# Altium schematic sheet ESSICC-ALM-INV-GAS.SchDoc(ESSICC-ALM-INV-GAS)
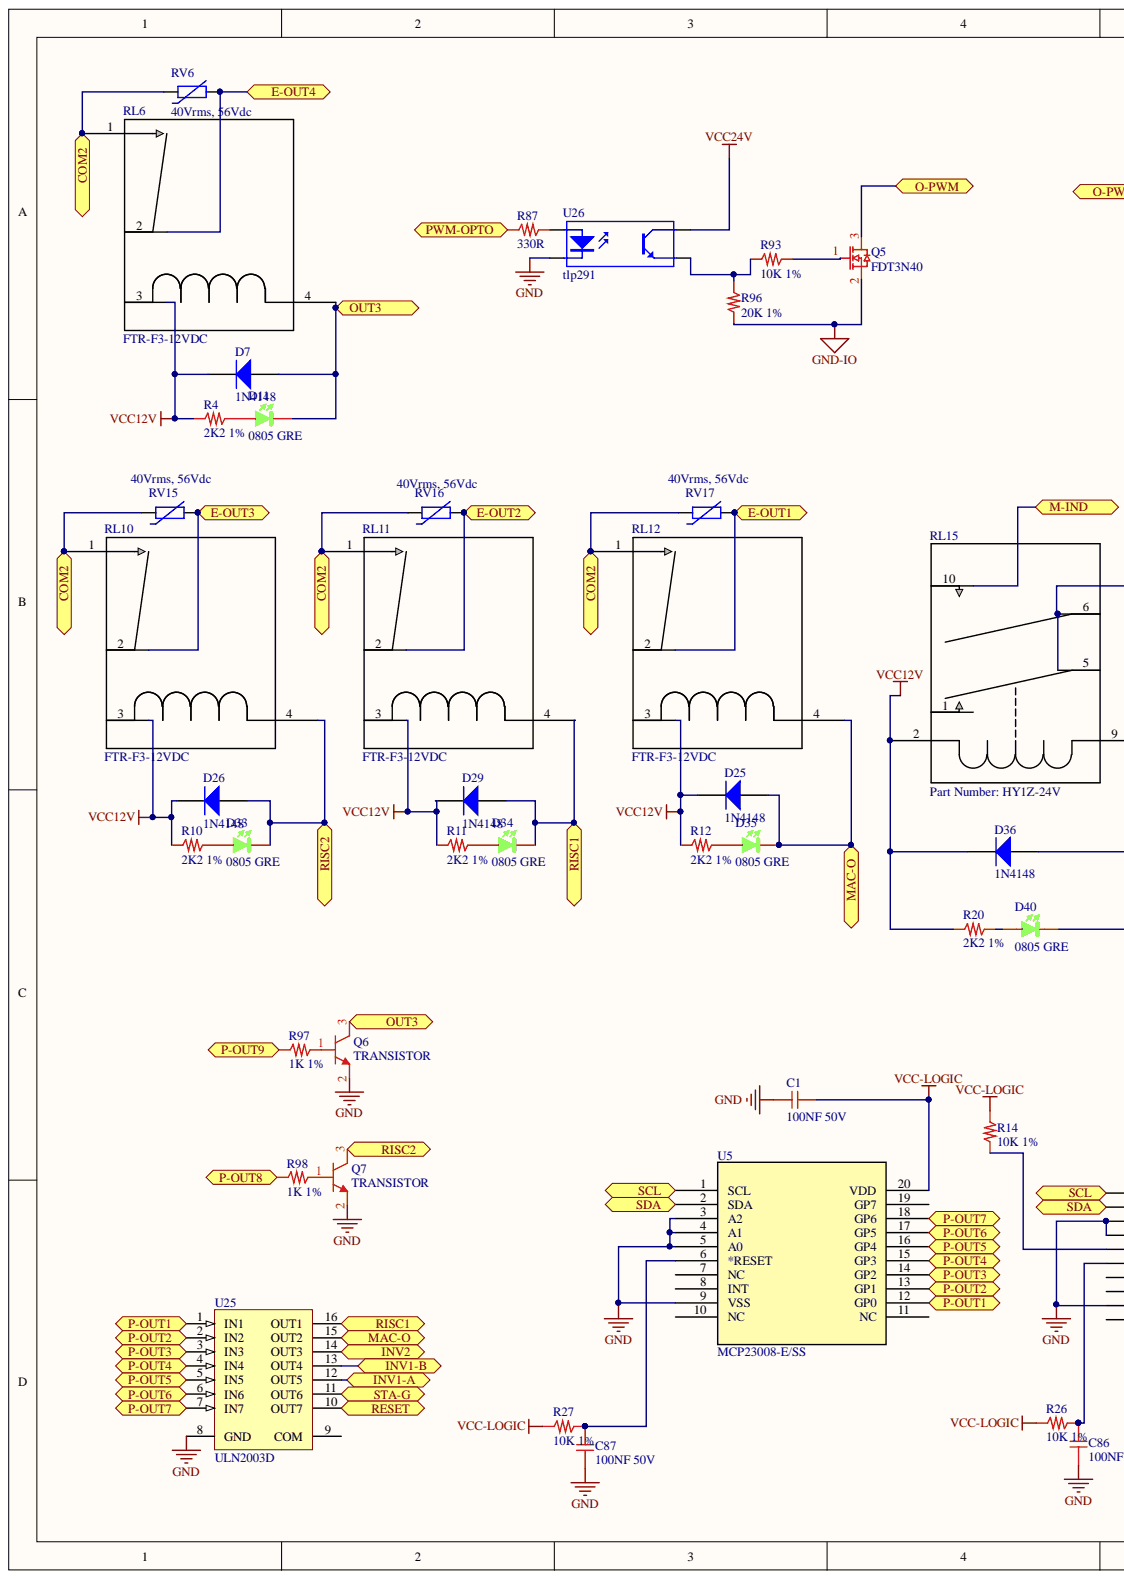
[diagram p0: ESSICC-ALM-INV-GAS.SchDoc, left]
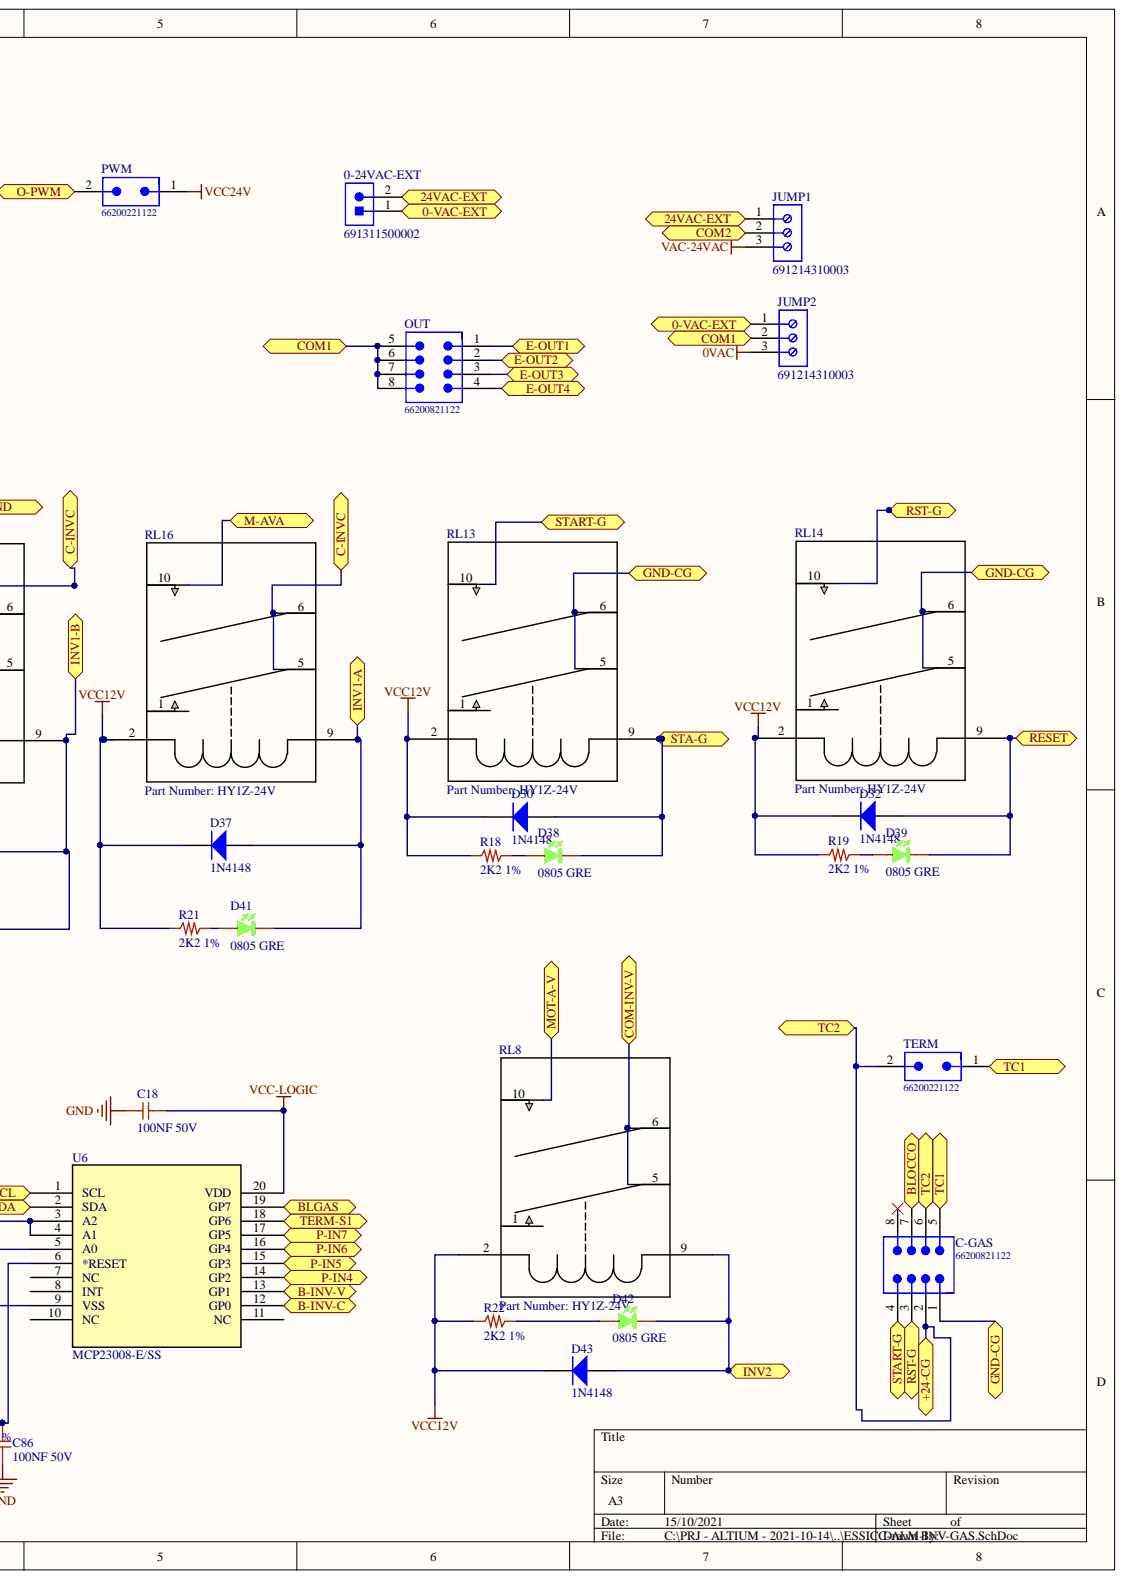
[diagram p1: ESSICC-ALM-INV-GAS.SchDoc, right]

## Parts
0-24VAC-EXT  691311500002  [691311500002]
C1  100NF 50V  [0603_c]
C18  100NF 50V  [0603_c]
C86  100NF 50V  [0603_c]
C87  100NF 50V  [0603_c]
C-GAS  66200821122  [66200821122]
D7  1N4148  [1N4148]
D11  0805 GRE  [0805_Green]
D25  1N4148  [1N4148]
D26  1N4148  [1N4148]
D29  1N4148  [1N4148]
D30  1N4148  [1N4148]
D32  1N4148  [1N4148]
D33  0805 GRE  [0805_Green]
D34  0805 GRE  [0805_Green]
D35  0805 GRE  [0805_Green]
D36  1N4148  [1N4148]
D37  1N4148  [1N4148]
D38  0805 GRE  [0805_Green]
D39  0805 GRE  [0805_Green]
D40  0805 GRE  [0805_Green]
D41  0805 GRE  [0805_Green]
D42  0805 GRE  [0805_Green]
D43  1N4148  [1N4148]
JUMP1  691214310003  [691214310003]
JUMP2  691214310003  [691214310003]
OUT  66200821122  [66200821122]
PWM  66200221122  [66200221122]
Q5  FDT3N40  [FDT3N40]
Q6  TRANSISTOR  [SOT23]
Q7  TRANSISTOR  [SOT23]
R4  2K2 1%  [0805_R]
R10  2K2 1%  [0805_R]
R11  2K2 1%  [0805_R]
R12  2K2 1%  [0805_R]
R14  10K 1%  [0603_R]
R18  2K2 1%  [0805_R]
R19  2K2 1%  [0805_R]
R20  2K2 1%  [0805_R]
R21  2K2 1%  [0805_R]
R22  2K2 1%  [0805_R]
R26  10K 1%  [0603_R]
R27  10K 1%  [0603_R]
R87  330R  [0603_R]
R93  10K 1%  [0603_R]
R96  20K 1%  [0603_R]
R97  1K 1%  [0603_R]
R98  1K 1%  [0603_R]
RL6  FTR-F3-12VDC  [PAN_ALD]
RL8  Part Number: HY1Z-24V  [RELAY_HY1]
RL10  FTR-F3-12VDC  [PAN_ALD]
RL11  FTR-F3-12VDC  [PAN_ALD]
RL12  FTR-F3-12VDC  [PAN_ALD]
RL13  Part Number: HY1Z-24V  [RELAY_HY1]
RL14  Part Number: HY1Z-24V  [RELAY_HY1]
RL15  Part Number: HY1Z-24V  [RELAY_HY1]
RL16  Part Number: HY1Z-24V  [RELAY_HY1]
RV6  40Vrms, 56Vdc  DC Operating Voltage: 56Vdc  [WE-VD_7mm_820574001]
RV15  40Vrms, 56Vdc  DC Operating Voltage: 56Vdc  [WE-VD_7mm_820574001]
RV16  40Vrms, 56Vdc  DC Operating Voltage: 56Vdc  [WE-VD_7mm_820574001]
RV17  40Vrms, 56Vdc  DC Operating Voltage: 56Vdc  [WE-VD_7mm_820574001]
TERM  66200221122  [66200221122]
U5  MCP23008-E/SS  [SSO-20]
U6  MCP23008-E/SS  [SSO-20]
U25  ULN2003D  [SO16_N]
U26  tlp291  [SO4_N]

## Ports
0-VAC-EXT, 24VAC-EXT, +24-CG, B-INV-C, B-INV-V, BLGAS, BLOCCO, C-INVC, COM1, COM2, COM-INV-V, E-OUT1, E-OUT2, E-OUT3, E-OUT4, GND-CG, INV1-A, INV1-B, INV2, M-AVA, M-IND, MAC-O, MOT-A-V, O-PWM, OUT3, P-IN4, P-IN5, P-IN6, P-IN7, P-OUT1, P-OUT2, P-OUT3, P-OUT4, P-OUT5, P-OUT6, P-OUT7, P-OUT8, P-OUT9, PWM-OPTO, RESET, RISC1, RISC2, RST-G, SCL, SDA, STA-G, START-G, TC1, TC2, TERM-S1

## Nets
0VAC: JUMP2.3
GND: C1.1 C18.1 C86.2 C87.2 Q6.2 Q7.2 U5.3(A2) U5.4(A1) U5.5(A0) U5.9(VSS) U6.3(A2) U6.4(A1) U6.9(VSS) U25.8(GND) U26.2
GND-IO: Q5.2 R96.2
VAC-24VAC: JUMP1.3
VCC12V: D7.2 D25.2 D26.2 D29.2 D30.2 D32.2 D36.2 D37.2 D43.2 R4.1 R10.1 R11.1 R12.1 R18.1 R19.1 R20.1 R21.1 R22.1 RL6.3 RL8.2 RL10.3 RL11.3 RL12.3 RL13.2 RL14.2 RL15.2 RL16.2
VCC24V: PWM.1 U26.4
VCC-LOGIC: C1.2 C18.2 R14.2 R26.1 R27.1 U5.20(VDD) U6.20(VDD)
0-VAC-EXT: 0-24VAC-EXT.1 JUMP2.1 ; port 0-VAC-EXT
24VAC-EXT: 0-24VAC-EXT.2 JUMP1.1 ; port 24VAC-EXT
+24-CG: C-GAS.2 C-GAS.6 TERM.2 ; port +24-CG, port TC2
B-INV-C: U6.12(GP0) ; port B-INV-C
B-INV-V: U6.13(GP1) ; port B-INV-V
BLGAS: U6.19(GP7) ; port BLGAS
BLOCCO: C-GAS.7 ; port BLOCCO
C-INVC: RL15.5 RL15.6 RL16.5 RL16.6 ; port C-INVC
COM1: JUMP2.2 OUT.5 OUT.6 OUT.7 OUT.8 ; port COM1
COM2: JUMP1.2 RL6.1 RL10.1 RL11.1 RL12.1 RV6.1 RV15.1 RV16.1 RV17.1 ; port COM2
COM-INV-V: RL8.5 RL8.6 ; port COM-INV-V
E-OUT1: OUT.1 RL12.2 RV17.2 ; port E-OUT1
E-OUT2: OUT.2 RL11.2 RV16.2 ; port E-OUT2
E-OUT3: OUT.3 RL10.2 RV15.2 ; port E-OUT3
E-OUT4: OUT.4 RL6.2 RV6.2 ; port E-OUT4
GND-CG: C-GAS.1 RL13.5 RL13.6 RL14.5 RL14.6 ; port GND-CG
INV1-A: D37.1 D41.K RL16.9 U25.12(OUT5) ; port INV1-A
INV1-B: D36.1 D40.K RL15.9 U25.13(OUT4) ; port INV1-B
INV2: D42.K D43.1 RL8.9 U25.14(OUT3) ; port INV2
M-AVA: RL16.10 ; port M-AVA
M-IND: RL15.10 ; port M-IND
MAC-O: D25.1 D35.K RL12.4 U25.15(OUT2) ; port MAC-O
MOT-A-V: RL8.10 ; port MOT-A-V
O-PWM: PWM.2 Q5.3 ; port O-PWM
OUT3: D7.1 D11.K Q6.3 RL6.4 ; port OUT3
P-IN4: U6.14(GP2) ; port P-IN4
P-IN5: U6.15(GP3) ; port P-IN5
P-IN6: U6.16(GP4) ; port P-IN6
P-IN7: U6.17(GP5) ; port P-IN7
P-OUT1: U5.12(GP0) U25.1(IN1) ; port P-OUT1
P-OUT2: U5.13(GP1) U25.2(IN2) ; port P-OUT2
P-OUT3: U5.14(GP2) U25.3(IN3) ; port P-OUT3
P-OUT4: U5.15(GP3) U25.4(IN4) ; port P-OUT4
P-OUT5: U5.16(GP4) U25.5(IN5) ; port P-OUT5
P-OUT6: U5.17(GP5) U25.6(IN6) ; port P-OUT6
P-OUT7: U5.18(GP6) U25.7(IN7) ; port P-OUT7
P-OUT8: R98.1 ; port P-OUT8
P-OUT9: R97.1 ; port P-OUT9
PWM-OPTO: R87.2 ; port PWM-OPTO
RESET: D32.1 D39.K RL14.9 U25.10(OUT7) ; port RESET
RISC1: D29.1 D34.K RL11.4 U25.16(OUT1) ; port RISC1
RISC2: D26.1 D33.K Q7.3 RL10.4 ; port RISC2
RST-G: C-GAS.3 RL14.10 ; port RST-G
SCL: U5.1(SCL) U6.1(SCL) ; port SCL
SDA: U5.2(SDA) U6.2(SDA) ; port SDA
STA-G: D30.1 D38.K RL13.9 U25.11(OUT6) ; port STA-G
START-G: C-GAS.4 RL13.10 ; port START-G
TC1: C-GAS.5 TERM.1 ; port TC1
TERM-S1: U6.18(GP6) ; port TERM-S1
NetC86_1: C86.1 R26.2 U6.6(*RESET)
NetC87_1: C87.1 R27.2 U5.6(*RESET)
NetD11_A: D11.A R4.2
NetD33_A: D33.A R10.2
NetD34_A: D34.A R11.2
NetD35_A: D35.A R12.2
NetD38_A: D38.A R18.2
NetD39_A: D39.A R19.2
NetD40_A: D40.A R20.2
NetD41_A: D41.A R21.2
NetD42_A: D42.A R22.2
NetQ5_1: Q5.1 R93.2
NetQ6_1: Q6.1 R97.2
NetQ7_1: Q7.1 R98.2
NetR14_1: R14.1 U6.5(A0)
NetR87_1: R87.1 U26.1
NetR93_1: R93.1 R96.1 U26.3

Not connected: C-GAS 8; RL8 1; RL13 1; RL14 1; RL15 1; RL16 1; U5 7(NC) 8(INT) 10(NC) 11(NC) 19(GP7); U6 7(NC) 8(INT) 10(NC) 11(NC); U25 9(COM)

## Library data of the parts
0-24VAC-EXT = 691311500002: WR-TBL Serie 311 Open Vertical PCB Header, Pluggable, pitch 5.08mm, 2p; Working Voltage [UL]: 300VAC; Rated Current [UL]: 20A; Pin Number: 2; Modularity: No; Compliance: RoHS; Type: Vertical; Published Date: October 2019; Pitch: 5.08mm; PCB/Cable/Panel: PCB; Part Number: 691311500002; Packaging: Box; Operating Temperature: -40 to +105degC; Mounting Technology: THT; Library Name: WR-TBL_Pluggable PCB Header.SchLib; ComponentLink2URL: https://katalog.we-online.de/em/datasheet/691311500002.pdf; ComponentLink2Description: Datasheet; ComponentLink1URL: http://www.we-online.de; ComponentLink1Description: Manufacturer; Component Kind: Standard; Application: Pluggable; Publisher: Wurth Elektronik
C1 C18 C86 C87 = 100NF 50V: Cap X7R 100nF 50V Flex Terminal; Produttore: Samsung; Cod. Produttore: CL10B104KB8SFNC; Cod. Interno: COCS50665050104; Library Name: CapSMD0603.SchLib; Component Kind: Standard; Cod. DigiKey: 1276-1936-2-ND
C-GAS OUT = 66200821122: THT Male Header WR-MPC3, Vertical, Dual Row, pitch 3 mm, 8 pins; Working Voltage: 250 VAC; Pitch: 3 mm; Pin Number: 8; Contact Resistance: 10 mOhm; Published Date: September 2018; Part Number: 66200821122; Packaging: Tube; Orientation: Vertical; Operating Temperature: -40 to 105 degC; Mounting Technology: THT; Library Name: WR-MPC3.SchLib; Gender: Male; ComponentLink2URL: https://katalog.we-online.de/em/datasheet/6620xx21122.pdf; ComponentLink2Description: Datasheet; ComponentLink1URL: http://www.we-online.de; ComponentLink1Description: Manufacturer; Component Kind: Standard; Publisher: Wurth Elektronik
D7 D25 D26 D29 D30 D32 D36 D37 D43 = 1N4148: High Conductance Fast Diode; Published: 8-Jun-2000; PackageReference: DO-35; PackageDescription: Diode, Axial; Body 3.8 x 1.9 mm (LxDia. max), Lead Dia. 0.53 mm (max); LatestRevisionNote: Re-released for DXP Platform.; LatestRevisionDate: 17-Jul-2002; DatasheetVersion: 1997; ComponentLink2URL: http://www.fairchildsemi.com/ds/1N%2F1N4148.pdf; ComponentLink2Description: Datasheet; ComponentLink1URL: http://www.fairchildsemi.com/; ComponentLink1Description: Manufacturer Link; Component Kind: Standard; Code_JEDEC: DO-204-AH; Publisher: Altium Limited; Library Name: HSW.SchLib
D11 D33-D35 D38-D42 = LED_Verde_0805: LED Verde 0805; V: 3.1V; Produttore: WE; Luminosità: 450mcd; Cod. Produttore: 150080GS75000; Cod. Interno: LEDS 9AC080GS7500; Component Kind: Standard; Library Name: DiodiLED.SchLib
JUMP1 JUMP2 = 691214310003: WR-TBL Serie 2143 Horizontal Entry Modular, Rising Cage Clamp, pitch 3.81mm, 3p; Working Voltage [UL]: 300VAC; Rated Current [UL]: 10A; Pin Number: 3; PCB/Cable/Panel: PCB; Modularity: Yes; Compliance: Lead free / RoHS; Type: Horizontal; Published Date: October 2019; Pitch: 3.81mm; Part Number: 691214310003; Packaging: Box; Operating Temperature: -40 to +105degC; Mounting Technology: THT; Library Name: WR-TBL_Rising Cage Clamp.SchLib; ComponentLink2URL: https://katalog.we-online.de/em/datasheet/691214310003.pdf; ComponentLink2Description: Datasheet; ComponentLink1URL: http://www.we-online.de; ComponentLink1Description: Manufacturer; Component Kind: Standard; Application: Rising Cage Clamp; Publisher: Wurth Elektronik
PWM TERM = 66200221122: THT Male Header WR-MPC3, Vertical, Dual Row, pitch 3 mm, 2 pins; Working Voltage: 250 VAC; Pitch: 3 mm; Pin Number: 2; Contact Resistance: 10 mOhm; Published Date: September 2018; Part Number: 66200221122; Packaging: Tube; Orientation: Vertical; Operating Temperature: -40 to 105 degC; Mounting Technology: THT; Library Name: WR-MPC3.SchLib; Gender: Male; ComponentLink2URL: https://katalog.we-online.de/em/datasheet/6620xx21122.pdf; ComponentLink2Description: Datasheet; ComponentLink1URL: http://www.we-online.de; ComponentLink1Description: Manufacturer; Component Kind: Standard; Publisher: Wurth Elektronik
Q5 = FDT3N40: N-Channel 20Vds 3Aid; Produttore: CT-Micro; Cod. Produttore: CT2300-R3; Component Kind: Standard; Library Name: HSW.SchLib
Q6 Q7 = BC847B: NPN Silicon Darlington Transistors; Vce: 30V; Vcb: 40V; Produttore: INFINEON; Ic: 500mA; Cod. Produttore: BCV27; Cod. Interno: TRXS ??; Component Kind: Standard; Library Name: HSW.SchLib
R4 R10-R12 R18-R22 = 2K2 1%: Res Film Spesso 0805 1/2W 2K2 1%; Cod. Interno: ??; Library Name: ResSMD0805.SchLib; Component Kind: Standard
R14 R26 R27 R93 = 10K 1%: Res Film Spesso 1/16W 10K 1%; Produttore: Panasonic; Cod. Produttore: ERJ-3EKF1002V; Cod. Interno: RESS4166356B0103; Library Name: ResSMD0603.SchLib; Component Kind: Standard
R87 = 330R: Res Film Spesso 1/16W 330R 5%; Cod. Interno: RESS4166556B0331; Library Name: ResSMD0603.SchLib; Component Kind: Standard
R96 = 20K 1%: Res Film Spesso 1/16W 20K 1%; Cod. Interno: RESS 4166360C0203; Library Name: ResSMD0603.SchLib; Component Kind: Standard
R97 R98 = 1K 1%: Res Film Spesso 1/16W 1K 1%; Produttore: ??; Cod. Interno: RESS4166356B0102; Library Name: ResSMD0603.SchLib; Component Kind: Standard; Cod. Produttore: ??
RL6 RL10-RL12 = FTR-F3-12VDC: RELE NA 5A 250VAC COIL12VDC; Manufacturer_Part_Number: ALDP106W; Vendor: Panasonic; Built_By: EMA_UL_Team; Value: Value; Type: DEV; RefDes: RefDes; Library Name: FTR-F3.SchLib; Component Kind: Standard; Copyright: Copyright (C) 2018 Accelerated Designs. All rights reserved
RL8 RL13-RL16 = HY1Z-24V: No Description Available; Vendor: Panasonic; Built_By: EMA_UL_Team; Value: Value; Type: DEV; RefDes: RefDes; Manufacturer_Part_Number: HY1Z-24V; Component Kind: Standard; Library Name: RELE-ST.SchLib; Copyright: Copyright (C) 2018 Accelerated Designs. All rights reserved
RV6 RV15-RV17 = 820574001: WE-VD Disk Varistor, size 7mm, 40Vrms, 56Vdc; Power Dissipation: 0.02W; Energy Absorbtion: 4.3J; Clamping Voltage: 135V; (Reverse) Peak Pulse Current: 250A; (Reverse) Breakdown Voltage: 68V; (Channel) Input Capacitance: 1120pF; Size: 7mm; Published Date: March 2019; Part Number: 820574001; Packaging: Tape and Reel; Operating Temperature: -40 to +85degC; Mounting Technology: THT; ComponentLink2URL: https://katalog.we-online.com/pbs/datasheet/820574001.pdf; ComponentLink2Description: Datasheet; ComponentLink1URL: http://www.we-online.de; ComponentLink1Description: Manufacturer; Component Kind: Standard; AC Operating Voltage: 40Vrms; Publisher: Wurth Elektronik; Library Name: MOV_7mm.SchLib
U5 U6 = MCP23008-E/SS: 8-Bit I/O Expander with Serial Interface; Mfr_Name: Microchip; Type: DEV; RefDes: RefDes; Manufacturer_Part_Number: MCP23008-E/SS; Digi-Key_Part_Number_1: MCP23008-E/SS-ND; Component Kind: Standard; Library Name: HSW.SchLib; Copyright: Copyright (C) 2021 Ultra Librarian. All rights reserved.
U25 = ULN2003D: Seven Darlington Array; Published: 8-Jun-2000; PackageVersion: May-1998; PackageReference: SO16; PackageDescription: 16-Pin Small Outline Integrated Circuit 1.27 mm Pitch; LatestRevisionNote: IPC-7351 Footprint Added.; LatestRevisionDate: 13-Apr-2006; DatasheetVersion: Sep-1998; ComponentLink2URL: http://www.st.com/stonline/products/literature/ds/5279/uln2001.pdf; ComponentLink2Description: Datasheet; ComponentLink1URL: http://www.st.com/; ComponentLink1Description: Manufacturer Link; Component Kind: Standard; Code_IPC: SOIC127P600-16; Publisher: Altium Limited; Library Name: HSW.SchLib
U26 = tlp291: 4-Pin Phototransistor Optocoupler; Published: 24-Mar-1999; PackageReference: DIP-4; LatestRevisionNote: Sch pins 3 & 4 Swapped.; LatestRevisionDate: 04-Mar-2005; DatasheetVersion: Nov-2003; Component Kind: Standard; Publisher: Altium Limited; Library Name: HSW.SchLib
